# Altium schematic sheet MCU.SchDoc(MCU)
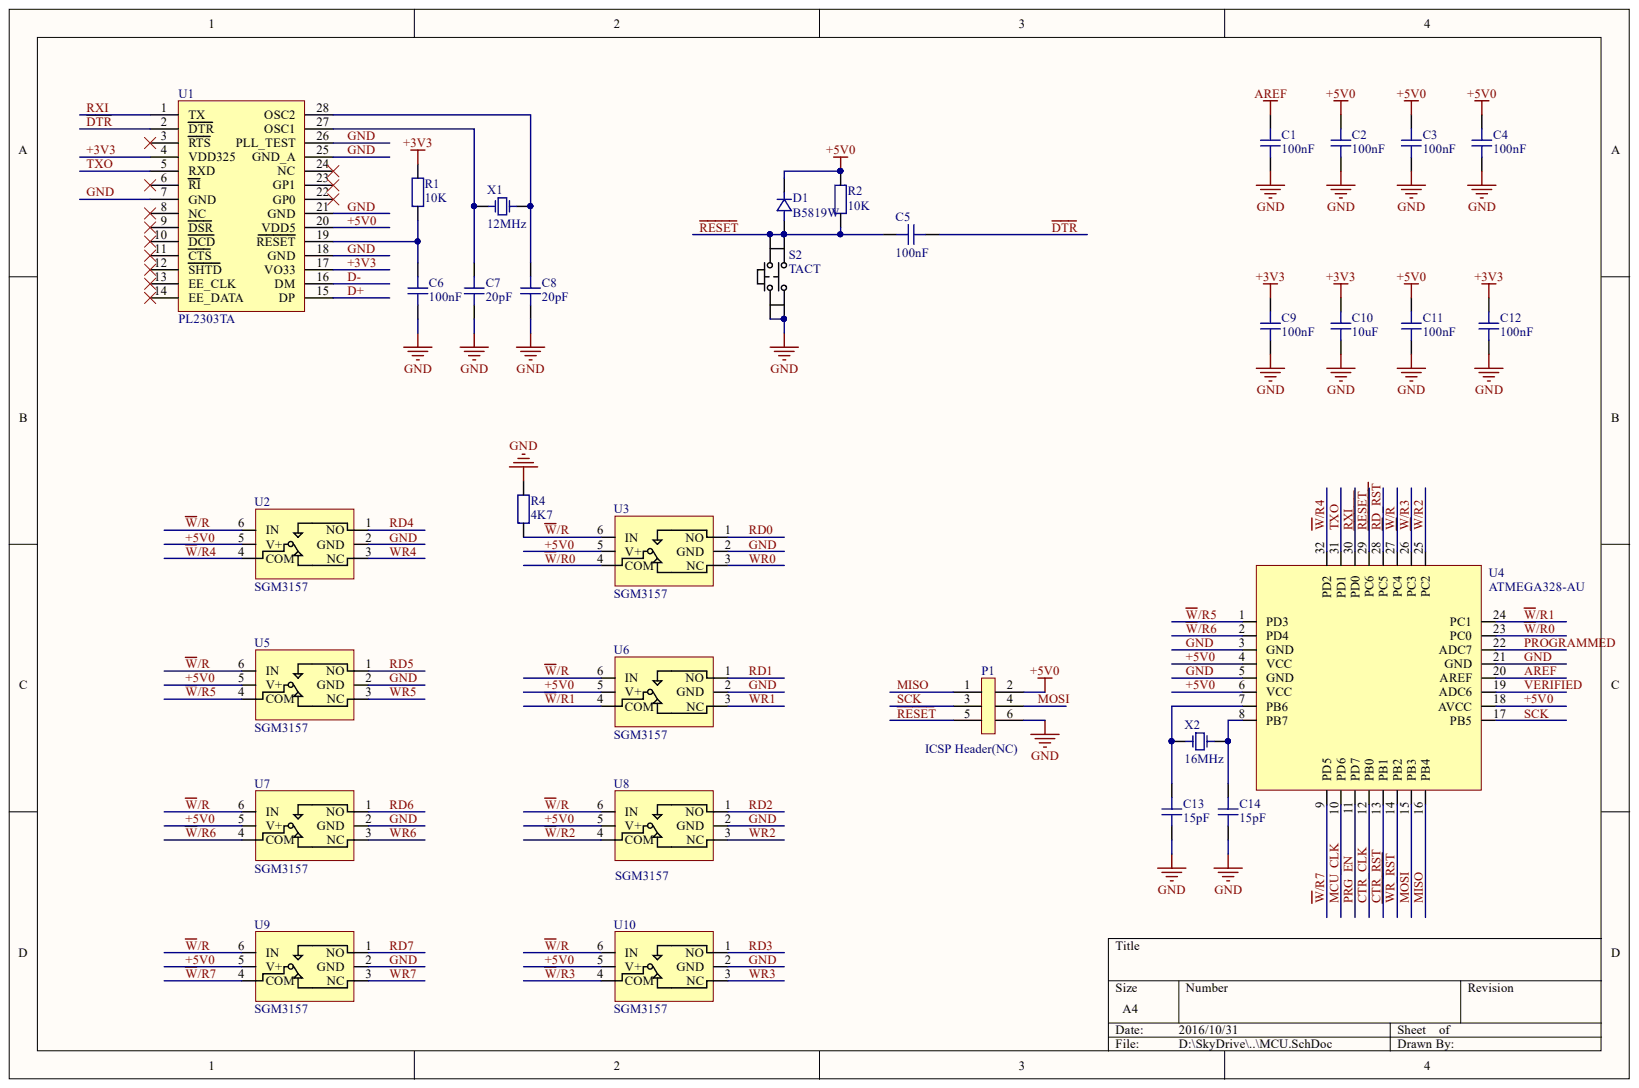
[diagram p0: MCU.SchDoc]

## Parts
C1  100nF  [CAPC2012N]
C2  100nF  [CAPC2012N]
C3  100nF  [CAPC2012N]
C4  100nF  [CAPC2012N]
C5  100nF  [CAPC2012N]
C6  100nF  [CAPC2012N]
C7  20pF  [CAPC2012N]
C8  20pF  [CAPC2012N]
C9  100nF  [CAPC2012N]
C10  10uF  [CAPC2012N]
C11  100nF  [CAPC2012N]
C12  100nF  [CAPC2012N]
C13  15pF  [CAPC2012N]
C14  15pF  [CAPC2012N]
D1  B5819W  [SOD3716X135N]
P1  ICSP Header(NC)  [HEADER2X3]
R1  10K  [RESC2012N]
R2  10K  [RESC2012N]
R4  4K7  [RESC2012N]
S2  TACT  [TACT_4X4]
U1  PL2303TA  [SOP65P780-28N]
U2  SGM3157  [SOT363-6N]
U3  SGM3157  [SOT363-6N]
U4  ATMEGA328-AU  [QFP80P900X900-32N]
U5  SGM3157  [SOT363-6N]
U6  SGM3157  [SOT363-6N]
U7  SGM3157  [SOT363-6N]
U8  SGM3157  [SOT363-6N]
U9  SGM3157  [SOT363-6N]
U10  SGM3157  [SOT363-6N]
X1  12MHz  [OSCCC250X320X100N]
X2  16MHz  [OSCCC250X320X100N]

## Nets
+3V3: C9.2 C10.2 C12.2 R1.1 U1.4(VDD325) U1.17(VO33)
+5V0: C2.2 C3.2 C4.2 C11.2 D1.1 P1.2 R2.2 U1.20(VDD5) U2.5(V+) U3.5(V+) U4.4(VCC) U4.6(VCC) U4.18(AVCC) U5.5(V+) U6.5(V+) U7.5(V+) U8.5(V+) U9.5(V+) U10.5(V+)
~{DTR}: C5.2 U1.2(DTR)
AREF: C1.2 U4.20(AREF)
CTR_CLK: U4.12(PB0)
CTR_RST: U4.13(PB1)
D+: U1.15(DP)
D-: U1.16(DM)
GND: C1.1 C2.1 C3.1 C4.1 C6.1 C7.1 C8.1 C9.1 C10.1 C11.1 C12.1 C13.1 C14.1 P1.6 R4.1 S2.2 S2.3 U1.7(GND) U1.18(GND) U1.21(GND) U1.25(GND_A) U1.26(PLL_TEST) U2.2(GND) U3.2(GND) U4.3(GND) U4.5(GND) U4.21(GND) U5.2(GND) U6.2(GND) U7.2(GND) U8.2(GND) U9.2(GND) U10.2(GND) X1.2 X1.4 X2.2 X2.4
MCU_CLK: U4.10(PD6)
MISO: P1.1 U4.16(PB4)
MOSI: P1.4 U4.15(PB3)
PRG_EN: U4.11(PD7)
PROGRAMMED: U4.22(ADC7)
~{RD_RST}: U4.28(PC5)
~{RESET}: C5.1 D1.2 P1.5 R2.1 S2.1 S2.4 U4.29(PC6)
RD0: U3.1(NO)
RD1: U6.1(NO)
RD2: U8.1(NO)
RD3: U10.1(NO)
RD4: U2.1(NO)
RD5: U5.1(NO)
RD6: U7.1(NO)
RD7: U9.1(NO)
RXI: U1.1(TX) U4.30(PD0)
SCK: P1.3 U4.17(PB5)
TXO: U1.5(RXD) U4.31(PD1)
VERIFIED: U4.19(ADC6)
~{W}/R: R4.2 U2.6(IN) U3.6(IN) U4.27(PC4) U5.6(IN) U6.6(IN) U7.6(IN) U8.6(IN) U9.6(IN) U10.6(IN)
~{W}/R0: U3.4(COM) U4.23(PC0)
~{W}/R1: U4.24(PC1) U6.4(COM)
~{W}/R2: U4.25(PC2) U8.4(COM)
~{W}/R3: U4.26(PC3) U10.4(COM)
~{W}/R4: U2.4(COM) U4.32(PD2)
~{W}/R5: U4.1(PD3) U5.4(COM)
~{W}/R6: U4.2(PD4) U7.4(COM)
~{W}/R7: U4.9(PD5) U9.4(COM)
~{WR_RST}: U4.14(PB2)
WR0: U3.3(NC)
WR1: U6.3(NC)
WR2: U8.3(NC)
WR3: U10.3(NC)
WR4: U2.3(NC)
WR5: U5.3(NC)
WR6: U7.3(NC)
WR7: U9.3(NC)
NetC6_2: C6.2 R1.2 U1.19(RESET)
NetC7_2: C7.2 U1.27(OSC1) X1.1
NetC8_2: C8.2 U1.28(OSC2) X1.3
NetC13_2: C13.2 U4.7(PB6) X2.1
NetC14_2: C14.2 U4.8(PB7) X2.3

Not connected: U1 3(RTS) 6(RI) 8(NC) 9(DSR) 10(DCD) 11(CTS) 12(SHTD) 13(EE_CLK) 14(EE_DATA) 22(GP0) 23(GP1) 24(NC)

## Library data of the parts
C1-C14 = CAP_CHP: Surface Mount Unpolarized Capacitor; Component Kind: Standard; Library Name: Discrete.SchLib
D1 = DIO_SMD: Surface Mount General Purpose Diode; Component Kind: Standard; Library Name: Discrete.SchLib
P1 = HDR2X3: Component Kind: Standard; Library Name: Connector.SchLib
R1 R2 R4 = RES_CHP: Surface Mount Resistor; Component Kind: Standard; Library Name: Discrete.SchLib
S2 = TACT_4PIN: Component Kind: Standard; Library Name: Discrete.SchLib
U1 = PL2303TA: USB to Serial Bridge Controller; Component Kind: Standard; Library Name: IC.SchLib
U4 = ATMEGA328-AU: 8-Bit Microcontroller; Component Kind: Standard; Library Name: IC.SchLib
X1 X2 = XTAL_SMD_4PIN: Surface Mount Crystal; Component Kind: Standard; Library Name: Discrete.SchLib
U2 U3 U5-U10 = SGM3157: Component Kind: Standard; Library Name: IC.SchLib
